# Altium schematic sheet RF.SchDoc(RF)
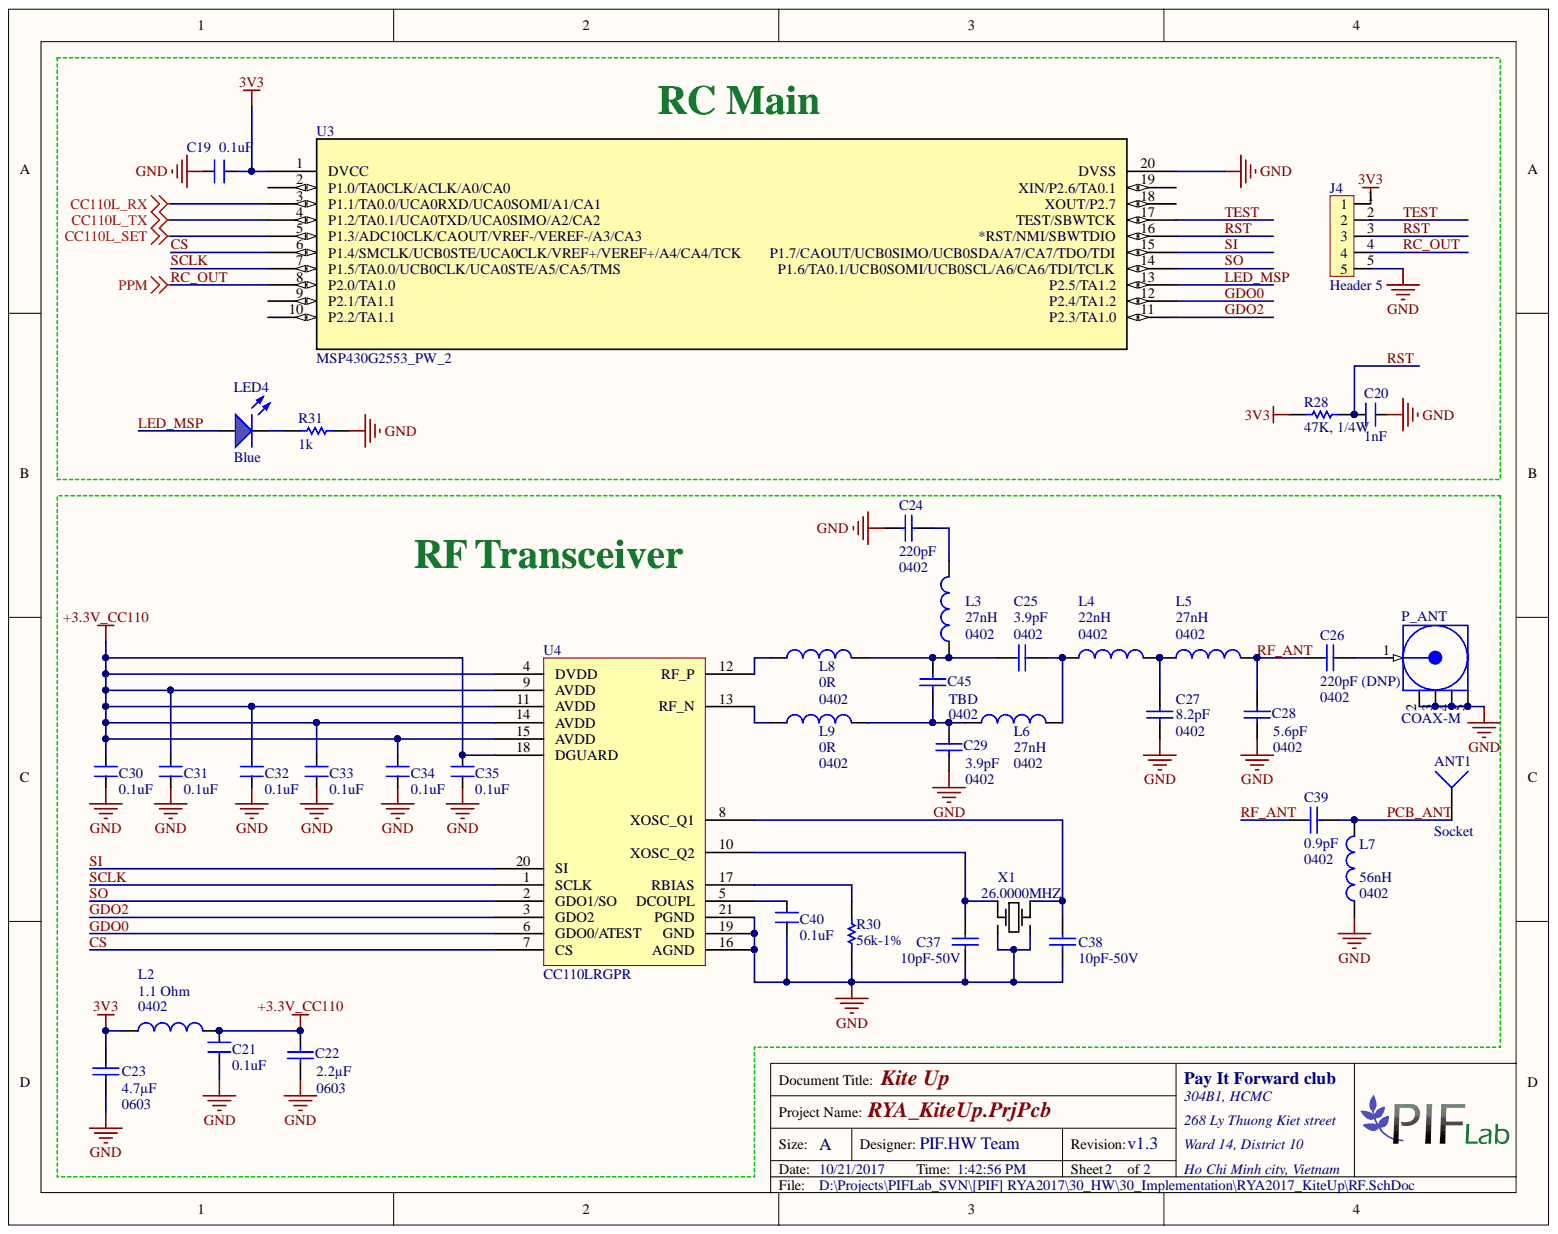
[diagram p0: RF.SchDoc]

## Parts
ANT1  Socket  [433_Antenna]
C19  0.1uF  [CAP 0603(1508)]
C20  1nF  [CAP 0603(1508)]
C21  0.1uF  [CAP 0603(1508)]
C22  Package / Case: 0603  Capacitance: 2.2µF  [CAP 0603(1508)]  (hidden comment: 2.2UF 10V X5R 0603)
C23  Package / Case: 0603  Capacitance: 4.7µF  [CAP 0603(1508)]  (hidden comment: 4.7UF 10V X5R 0603)
C24  Capacitance: 220pF  [C0402_TYPE2]
C25  Package / Case: 0402  Capacitance: 3.9pF  [C0402_TYPE2]
C26  Package / Case: 0402  [C0402_TYPE2]
C27  Package / Case: 0402  Capacitance: 8.2pF  [C0402_TYPE2]
C28  Package / Case: 0402  Capacitance: 5.6pF  [C0402_TYPE2]
C29  Package / Case: 0402  Capacitance: 3.9pF  [C0402_TYPE2]
C30  0.1uF  [CAP 0603(1508)]
C31  0.1uF  [CAP 0603(1508)]
C32  0.1uF  [CAP 0603(1508)]
C33  0.1uF  [CAP 0603(1508)]
C34  0.1uF  [CAP 0603(1508)]
C35  0.1uF  [CAP 0603(1508)]
C37  10pF-50V  [C0402_TYPE2]
C38  10pF-50V  [C0402_TYPE2]
C39  Capacitance: 0.9pF  [C0402_TYPE2]
C40  0.1uF  [CAP 0603(1508)]
C45  Package / Case: 0402  Capacitance: TBD  [C0402_TYPE2]  (no BOM)
J4  Header 5  [Header 5]
L2  Package / Case: 0402  [L0402_TYPE2]
L3  Package / Case: 0402  Inductance: 27nH  [L0402_TYPE2]
L4  Package / Case: 0402  [L0402_TYPE2]
L5  Package / Case: 0402  [L0402_TYPE2]
L6  Package / Case: 0402  Inductance: 27nH  [L0402_TYPE2]
L7  Package / Case: 0402  Inductance: 56nH  [L0402_TYPE2]
L8  Inductance: 0R  [L0402_TYPE2]
L9  Package / Case: 0402  Inductance: 0R  [L0402_TYPE2]
LED4  value Blue  [LED0805BLUE]  (hidden comment: LED_BLUE)
P_ANT  COAX-M  [MMCX2.54-V5]
R28  47K, 1/4W  [RES 0603(1508)]
R30  56k-1%  [R0402_TYPE2]
R31  1k  [RES 0603(1508)]
U3  MSP430G2553_PW_2  [PW20]
U4  CC110LRGPR  [VQFN20]
X1  26.0000MHZ  [Crystal-3.2x2.5]

## Nets
3V3: C19.1 C23.2 J4.1 L2.2 R28.1 U3.1(DVCC)
+3.3V_CC110: C21.2 C22.2 C30.2 C31.2 C32.2 C33.2 C34.2 C35.2 L2.1 U4.4(DVDD) U4.9(AVDD) U4.11(AVDD) U4.14(AVDD) U4.15(AVDD) U4.18(DGUARD)
CC110L_RX: U3.3(P1.1/TA0.0/UCA0RXD/UCA0SOMI/A1/CA1)
CC110L_SET: U3.5(P1.3/ADC10CLK/CAOUT/VREF-/VEREF-/A3/CA3)
CC110L_TX: U3.4(P1.2/TA0.1/UCA0TXD/UCA0SIMO/A2/CA2)
GND: C19.2 C20.2 C21.1 C22.1 C23.1 C24.1 C27.1 C28.1 C29.2 C30.1 C31.1 C32.1 C33.1 C34.1 C35.1 C37.1 C38.1 C40.1 J4.5 L7.2 P_ANT.2 P_ANT.3 P_ANT.4 P_ANT.5 R30.1 R31.1 U3.20(DVSS) U4.16(AGND) U4.19(GND) U4.21(PGND) X1.2 X1.4
CS: U3.6(P1.4/SMCLK/UCB0STE/UCA0CLK/VREF+/VEREF+/A4/CA4/TCK) U4.7(CS)
GDO0: U3.12(P2.4/TA1.2) U4.6(GDO0/ATEST)
GDO2: U3.11(P2.3/TA1.0) U4.3(GDO2)
LED_MSP: LED4.1 U3.13(P2.5/TA1.2)
PCB_ANT: ANT1.1 C39.2 L7.1
RC_OUT: J4.4 U3.8(P2.0/TA1.0)
RF_ANT: C26.1 C28.2 C39.1 L5.1
RST: C20.1 J4.3 R28.2 U3.16(*RST/NMI/SBWTDIO)
SCLK: U3.7(P1.5/TA0.0/UCB0CLK/UCA0STE/A5/CA5/TMS) U4.1(SCLK)
SI: U3.15(P1.7/CAOUT/UCB0SIMO/UCB0SDA/A7/CA7/TDO/TDI) U4.20(SI)
SO: U3.14(P1.6/TA0.1/UCB0SOMI/UCB0SCL/A6/CA6/TDI/TCLK) U4.2(GDO1/SO)
TEST: J4.2 U3.17(TEST/SBWTCK)
NetC24_2: C24.2 L3.1
NetC25_1: C25.1 C45.1 L3.2 L8.1
NetC25_2: C25.2 L4.2 L6.1
NetC26_2: C26.2 P_ANT.1
NetC27_2: C27.2 L4.1 L5.2
NetC29_1: C29.1 C45.2 L6.2 L9.1
NetC37_2: C37.2 U4.10(XOSC_Q2) X1.1
NetC38_2: C38.2 U4.8(XOSC_Q1) X1.3
NetC40_2: C40.2 U4.5(DCOUPL)
NetL8_2: L8.2 U4.12(RF_P)
NetL9_2: L9.2 U4.13(RF_N)
NetLED4_2: LED4.2 R31.2
NetR30_2: R30.2 U4.17(RBIAS)

Not connected: U3 2(P1.0/TA0CLK/ACLK/A0/CA0) 9(P2.1/TA1.1) 10(P2.2/TA1.1) 18(XOUT/P2.7) 19(XIN/P2.6/TA0.1)

## Library data of the parts
C19-C21 C30-C35 C40 = CAP_NONPOL: Ceramic capacitor; Component Kind: Standard; Library Name: libbeagle.SchLib
C22 = 100nF-16V-C0402: CAP CER 2.2UF 10V X5R 0603; Manufacturer Part Number: CC0603KRX5R6BB225; Manufacturer: Yageo; Tolerance: ±10%; Voltage - Rated: 10V; Thickness (Max): 0.035" (0.90mm); Temperature Coefficient: X5R; Supplier Part Number 1: 311-1451-2-ND; Supplier 1: Digi-Key; Stock 1: 360000; Size / Dimension: 0.063" L x 0.031" W (1.60mm x 0.80mm); RoHS: RoHS Compliant; Ratings: -; Pricing 1: 4000=0.01939, 8000=0.01804, 12000=0.01714, 28000=0.01624, 100000=0.01229 (USD); Packaging: Tape & Reel (TR); Operating Temperature: -55°C ~ 85°C; Mounting Type: Surface Mount, MLCC; Library Name: Capacitor_0402.SchLib; Lead Style: -; Lead Spacing: -; Height - Seated (Max): -; Features: -; ComponentLink1URL: http://www.yageo.com/documents/recent/UPY-GPHC_X5R_4V-to-50V-21.pdf; ComponentLink1Description: http://www.yageo.com/documents/recent/UPY-GPHC_X5R_4V-to-50V-21.pdf; Component Kind: Standard; Category: Capacitors; Applications: General Purpose
C23 = 10pF-50V-C0402-5%: CAP CER 4.7UF 10V X5R 0603; Manufacturer Part Number: CC0603KRX5R6BB475; Manufacturer: Yageo; Tolerance: ±10%; Voltage - Rated: 10V; Thickness (Max): 0.035" (0.90mm); Temperature Coefficient: X5R; Supplier Part Number 1: 311-1455-1-ND; Supplier 1: Digi-Key; Stock 1: 521169; Size / Dimension: 0.063" L x 0.031" W (1.60mm x 0.80mm); RoHS: RoHS Compliant; Ratings: -; Pricing 1: 1=0.15, 10=0.1, 25=0.0752, 100=0.0476, 250=0.04008, 500=0.03356, 1000=0.02755 (USD); Packaging: Cut Tape (CT); Operating Temperature: -55°C ~ 85°C; Mounting Type: Surface Mount, MLCC; Library Name: Capacitor_0402.SchLib; Lead Style: -; Lead Spacing: -; Height - Seated (Max): -; Features: -; ComponentLink1URL: http://www.yageo.com/documents/recent/UPY-GPHC_X5R_4V-to-50V-21.pdf; ComponentLink1Description: http://www.yageo.com/documents/recent/UPY-GPHC_X5R_4V-to-50V-21.pdf; Component Kind: Standard; Category: Capacitors; Applications: General Purpose
C24 C39 = 3.3pF-50V-C0402-0.25pF: CAP CER 3.9PF 50V NP0 0402; Manufacturer Part Number: GRM1555C1H221JA01D; Manufacturer: Murata Electronics North America; Tolerance: ±5%; Temperature Coefficient: C0G, NP0; Voltage - Rated: 50V; Thickness (Max): 0.022" (0.55mm); Supplier Part Number 1: 490-1293-2-ND; Supplier 1: Digi-Key; Stock 1: 470000; Size / Dimension: 0.039" L x 0.020" W (1.00mm x 0.50mm); RoHS: RoHS Compliant; Ratings: -; Pricing 1: 10000=0.00336, 20000=0.0032, 30000=0.00304, 50000=0.00272, 100000=0.0022 (USD); Packaging: Tape & Reel (TR); Package / Case: 0402; Operating Temperature: -55°C ~ 125°C; Mounting Type: Surface Mount, MLCC; Library Name: Capacitor_0402.SchLib; Lead Style: -; Lead Spacing: -; Height - Seated (Max): -; Features: -; ComponentLink1URL: http://www.murata.com/~/media/webrenewal/support/library/catalog/products/capacitor/mlcc/c02e.pdf; ComponentLink1Description: http://www.murata.com/~/media/webrenewal/support/library/catalog/products/capacitor/mlcc/c02e.pdf; Component Kind: Standard; Category: Capacitors; Applications: General Purpose
C25 C29 C45 = 3.3pF-50V-C0402-0.25pF: CAP CER 3.9PF 50V NP0 0402; Manufacturer Part Number: GRM1555C1H3R9CZ01D; Manufacturer: Murata Electronics North America; Tolerance: ±0.25pF; Temperature Coefficient: C0G, NP0; Voltage - Rated: 50V; Thickness (Max): 0.022" (0.55mm); Supplier Part Number 1: 490-1272-2-ND; Supplier 1: Digi-Key; Stock 1: 0; Size / Dimension: 0.039" L x 0.020" W (1.00mm x 0.50mm); RoHS: RoHS Compliant; Ratings: -; Pricing 1: 10000=0 (USD); Packaging: Tape & Reel (TR); Operating Temperature: -55°C ~ 125°C; Mounting Type: Surface Mount, MLCC; Library Name: Capacitor_0402.SchLib; Lead Style: -; Lead Spacing: -; Height - Seated (Max): -; Features: -; ComponentLink1URL: http://media.digikey.com/pdf/Data%20Sheets/Murata%20PDFs/Chip%20Monolithic%20Ceramic%20Caps.pdf; ComponentLink1Description: http://media.digikey.com/pdf/Data%20Sheets/Murata%20PDFs/Chip%20Monolithic%20Ceramic%20Caps.pdf; Category: Capacitors; Applications: General Purpose
  C25 C29: Component Kind: Standard
C26 = 3.3pF-50V-C0402-0.25pF: CAP CER 3.9PF 50V NP0 0402; Manufacturer Part Number: GRM1555C1H221JA01D; Manufacturer: Murata Electronics North America; Tolerance: ±5%; Temperature Coefficient: C0G, NP0; Capacitance: 220pF (DNP); Voltage - Rated: 50V; Thickness (Max): 0.022" (0.55mm); Supplier Part Number 1: 490-1293-2-ND; Supplier 1: Digi-Key; Stock 1: 470000; Size / Dimension: 0.039" L x 0.020" W (1.00mm x 0.50mm); RoHS: RoHS Compliant; Ratings: -; Pricing 1: 10000=0.00336, 20000=0.0032, 30000=0.00304, 50000=0.00272, 100000=0.0022 (USD); Packaging: Tape & Reel (TR); Operating Temperature: -55°C ~ 125°C; Mounting Type: Surface Mount, MLCC; Library Name: Capacitor_0402.SchLib; Lead Style: -; Lead Spacing: -; Height - Seated (Max): -; Features: -; ComponentLink1URL: http://www.murata.com/~/media/webrenewal/support/library/catalog/products/capacitor/mlcc/c02e.pdf; ComponentLink1Description: http://www.murata.com/~/media/webrenewal/support/library/catalog/products/capacitor/mlcc/c02e.pdf; Component Kind: Standard; Category: Capacitors; Applications: General Purpose
C27 = 8.2pF-50V-C0402-0.5pF: GRM1555C1H8R2CZ01D; Manufacturer: Murata Electronics North America; Voltage - Rated: 50V; Tolerance: ±0.25pF; Temperature Coefficient: C0G, NP0; Thickness (Max): 0.022" (0.55mm); Supplier Part Number 1: GRM1555C1H8R2CZ01D-ND; Supplier 1: Digi-Key; Stock 1: 0; Size / Dimension: 0.039" L x 0.020" W (1.00mm x 0.50mm); RoHS: RoHS Compliant; Ratings: -; Pricing 1: 60000=0 (USD); Packaging: Tape & Reel (TR); Operating Temperature: -55°C ~ 125°C; Mounting Type: Surface Mount, MLCC; Manufacturer Part Number: GRM1555C1H8R2CZ01D; Library Name: Capacitor_0402.SchLib; Lead Style: -; Lead Spacing: -; Height - Seated (Max): -; Features: -; ComponentLink1URL: http://media.digikey.com/pdf/Data%20Sheets/Murata%20PDFs/Chip%20Monolithic%20Ceramic%20Caps.pdf; ComponentLink1Description: http://media.digikey.com/pdf/Data%20Sheets/Murata%20PDFs/Chip%20Monolithic%20Ceramic%20Caps.pdf; Component Kind: Standard; Category: Capacitors; Applications: General Purpose
C28 = 5.6pF-50V-C0402-0.5pF: CAP CER 5.6PF 50V NP0 0402; Manufacturer Part Number: GRM1555C1H5R6CZ01D; Manufacturer: Murata Electronics North America; Tolerance: ±0.25pF; Temperature Coefficient: C0G, NP0; Voltage - Rated: 50V; Thickness (Max): 0.022" (0.55mm); Supplier Part Number 1: GRM1555C1H5R6CZ01D-ND; Supplier 1: Digi-Key; Stock 1: 0; Size / Dimension: 0.039" L x 0.020" W (1.00mm x 0.50mm); RoHS: RoHS Compliant; Ratings: -; Pricing 1: 60000=0 (USD); Packaging: Tape & Reel (TR); Operating Temperature: -55°C ~ 125°C; Mounting Type: Surface Mount, MLCC; Library Name: Capacitor_0402.SchLib; Lead Style: -; Lead Spacing: -; Height - Seated (Max): -; Features: -; ComponentLink1URL: http://media.digikey.com/pdf/Data%20Sheets/Murata%20PDFs/Chip%20Monolithic%20Ceramic%20Caps.pdf; ComponentLink1Description: http://media.digikey.com/pdf/Data%20Sheets/Murata%20PDFs/Chip%20Monolithic%20Ceramic%20Caps.pdf; Component Kind: Standard; Category: Capacitors; Applications: General Purpose
C37 C38 = 10pF-50V-C0402-5%: CAP CER 10PF 50V NPO 0402; Manufacturer Part Number: CC0402JRNPO9BN100; Manufacturer: Yageo; Unit Price: 0.00312; Library Name: Capacitor_0402.SchLib; Digi-Key Part Number: 311-1014-1-ND; Component Kind: Standard
L2 = 8.2nH-500mA-0402: FERRITE BEAD 1 KOHM 0402 1LN; Manufacturer Part Number: BLM15HG102SN1D; Manufacturer: Murata Electronics North America; Number of Lines: 1; Impedance @ Frequency: 1 kOhm @ 100MHz; Filter Type: Signal Line; DC Resistance (DCR) (Max): 1.1 Ohm; Current Rating (Max): 250mA; Supplier Part Number 1: 490-3999-2-ND; Supplier 1: Digi-Key; Stock 1: 230000; Size / Dimension: 0.039" L x 0.020" W (1.00mm x 0.50mm); RoHS: RoHS Compliant; Ratings: -; Pricing 1: 10000=0.0509, 20000=0.04844, 30000=0.04713 (USD); Packaging: Tape & Reel (TR); Operating Temperature: -55°C ~ 125°C; Mounting Type: Surface Mount; Library Name: Inductor.SchLib; Height (Max): 0.022" (0.55mm); ComponentLink1URL: http://search.murata.co.jp/Ceramy/image/img/PDF/ENG/L0110S0101BLM15H.pdf; ComponentLink1Description: http://search.murata.co.jp/Ceramy/image/img/PDF/ENG/L0110S0101BLM15H.pdf; Component Kind: Standard; Category: Filters
L3 L5-L9 = 8.2nH-500mA-0402: FIXED IND 27NH 300MA 460 MOHM; Manufacturer Part Number: LQG15HS27NJ02D; Manufacturer: Murata Electronics North America; Type: Multilayer; Tolerance: ±5%; Shielding: Unshielded; Q @ Freq: 8 @ 100MHz; Material - Core: Air; Frequency - Test: 100MHz; Frequency - Self Resonant: 1.7GHz; DC Resistance (DCR): 460 mOhm Max; Supplier Part Number 1: 490-2628-2-ND; Supplier 1: Digi-Key; Stock 1: 120000; Size / Dimension: 0.039" L x 0.020" W (1.00mm x 0.50mm); RoHS: RoHS Compliant; Ratings: -; Pricing 1: 10000=0.01872, 30000=0.01814, 50000=0.01755, 100000=0.01697 (USD); Packaging: Tape & Reel (TR); Operating Temperature: -55°C ~ 125°C; Mounting Type: Surface Mount; Library Name: Inductor.SchLib; Height - Seated (Max): 0.022" (0.55mm); Current Rating: 300mA; Current - Saturation: -; ComponentLink1URL: http://search.murata.co.jp/Ceramy/image/img/PDF/ENG/L0075S0083LQG15HS.pdf; ComponentLink1Description: http://search.murata.co.jp/Ceramy/image/img/PDF/ENG/L0075S0083LQG15HS.pdf; Component Kind: Standard; Category: Inductors, Coils, Chokes
  L5: Inductance: 27nH
  L8: Package / Case: 0402
L4 = 8.2nH-500mA-0402: FIXED IND 22NH 300MA 420 MOHM; Manufacturer Part Number: LQG15HS22NJ02D; Manufacturer: Murata Electronics North America; Type: Multilayer; Tolerance: ±5%; Shielding: Unshielded; Q @ Freq: 8 @ 100MHz; Material - Core: Air; Frequency - Test: 100MHz; Frequency - Self Resonant: 1.9GHz; DC Resistance (DCR): 420 mOhm Max; Supplier Part Number 1: 490-2627-1-ND; Supplier 1: Digi-Key; Stock 1: 250711; Size / Dimension: 0.039" L x 0.020" W (1.00mm x 0.50mm); RoHS: RoHS Compliant; Ratings: -; Pricing 1: 1=0.1, 10=0.059, 25=0.0528, 50=0.0456, 100=0.041, 250=0.03804, 500=0.0351, 750=0.03335, 1000=0.03042 (USD); Packaging: Cut Tape (CT); Operating Temperature: -55°C ~ 125°C; Mounting Type: Surface Mount; Library Name: Inductor.SchLib; Inductance: 22nH; Height - Seated (Max): 0.022" (0.55mm); Current Rating: 300mA; Current - Saturation: -; ComponentLink1URL: http://search.murata.co.jp/Ceramy/image/img/PDF/ENG/L0075S0083LQG15HS.pdf; ComponentLink1Description: http://search.murata.co.jp/Ceramy/image/img/PDF/ENG/L0075S0083LQG15HS.pdf; Component Kind: Standard; Category: Inductors, Coils, Chokes
LED4 = LED_BLUE: LED Blue; Unit Price: 0.0476; ComponentLink1Description: Datasheet; Component Kind: Standard; Library Name: libbeagle.SchLib; ComponentLink1URL: D:\Dropbox\Datasheets\Opto and Illumination\LEDs\SMD LED.pdf
P_ANT = COAX-M: RF Coaxial PCB Connector, MMCX; Thru-Hole, Vertical Mount Plug,  50 Ohm Impedance; PCB_Layout: Complies with manufacturer's recommendation.; PackageDocument: Rev. A, 16-Jun-1997; DrillSize: Corresponds to nominal value.; Published: 15-Jul-2004; PackageReference: 908-21103; Library Name: Miscellaneous Connectors.IntLib; LatestRevisionNote: Component Replaced.; LatestRevisionDate: 15-Jul-2004; DatasheetDocument: 30-May-2003; ComponentLink1URL: http://www.amphenolrf.com/; ComponentLink1Description: Manufacturer Link; Component Kind: Standard; Publisher: Altium Limited
R28 R31 = Res 1/4W: Resistor through hole 1/4W; Component Kind: Standard; Library Name: libbeagle.SchLib
R30 = 56k-1%-R0402: RES 56.0K OHM 1/16W 1% 0402 SMD; Manufacturer Part Number: RC0402FR-0756KL; Manufacturer: Yageo; Unit Price: 0.00149; Library Name: Resistor_0402.SchLib; Digi-Key Part Number: 311-56.0KLRTR-ND; Component Kind: Standard
U3 = MSP430G2553_PW_2: Imported; Manufacturer_Part_Number: msp430g2553_pw_20; Vendor: Texas Instruments; PIN_COUNT: 20; PACKAGE_DESIGNATOR: PW; INDUSTRY_STD_PKG_TYPE: TSSOP; FAMILY_NAME: Value Line; Value: Value; Type: Type; RefDes: RefDes; Manufacturer: Texas Instruments; Library Name: msp430g2553.SchLib; GENERIC_PART_NUMBER: MSP430G2553; Datasheet_URL: http://www.ti.com/lit/gpn/MSP430G2553; Component Kind: Standard
U4 = CC110LRGPR: IC RF TXRX ISM<1GHZ 20-VFQFN; Manufacturer: Texas Instruments; Voltage - Supply: 1.8 V ~ 3.6 V; Serial Interfaces: SPI; Sensitivity: -116dBm; RF Family/Standard: General ISM < 1GHz; Power - Output: 12dBm; Modulation: 2FSK, 4FSK, GFSK, MSK, OOK; Frequency: 300MHz ~ 348MHz, 387MHz ~ 464MHz, 779MHz ~ 928MHz; Data Rate (Max): 600kbps; Current - Transmitting: 12.3mA ~ 34.2mA; Current - Receiving: 14.3mA ~ 17.1mA; Type: TxRx Only; Supplier Part Number 1: 296-35719-2-ND; Supplier 1: Digi-Key; Stock 1: 0; RoHS: RoHS Compliant; Protocol: -; Pricing 1: 3000=1.9285, 6000=1.84875, 15000=1.8125 (USD); Packaging: Tape & Reel (TR); Package / Case: 20-VFQFN Exposed Pad; Operating Temperature: -40°C ~ 85°C; Memory Size: -; Manufacturer Part Number: CC110LRGPR; Library Name: Schlib1.SchLib; GPIO: -; ComponentLink1URL: http://www.ti.com/lit/ds/symlink/cc110l.pdf; ComponentLink1Description: http://www.ti.com/lit/ds/symlink/cc110l.pdf; Component Kind: Standard; Category: RF/IF and RFID
X1 = CX3225SB26000D0FFFCC: CRYSTAL 26.0000MHZ 8PF SMD; Manufacturer: AVX Corp/Kyocera Corp; Operating Mode: Fundamental; Frequency Tolerance: ±10ppm; Frequency Stability: ±15ppm; Frequency: 26MHz; ESR (Equivalent Series Resistance): 50 Ohm; Type: MHz Crystal; Supplier Part Number 1: 1253-1354-2-ND; Supplier 1: Digi-Key; Stock 1: 3000; Size / Dimension: 0.126" L x 0.098" W (3.20mm x 2.50mm); RoHS: RoHS Compliant; Ratings: -; Pricing 1: 3000=0.406, 6000=0.392, 15000=0.378 (USD); Packaging: Tape & Reel (TR); Package / Case: 4-SMD, No Lead (DFN, LCC); Operating Temperature: -20°C ~ 70°C; Mounting Type: Surface Mount; Manufacturer Part Number: CX3225SB26000D0FFFCC; Load Capacitance: 8pF; Library Name: Schlib1.SchLib; Height: 0.024" (0.60mm); ComponentLink1URL: http://media.digikey.com/pdf/Data%20Sheets/Optrex%20PDFs/CX3225SByyy00D0FFFCC_Spec.pdf; ComponentLink1Description: http://media.digikey.com/pdf/Data%20Sheets/Optrex%20PDFs/CX3225SByyy00D0FFFCC_Spec.pdf; Component Kind: Standard; Category: Crystals and Oscillators
ANT1 = Socket: Signal Integrity: Connector; Library Name: Miscellaneous Connectors.IntLib; LatestRevisionNote: Re-released for DXP Platform.; LatestRevisionDate: 17-Jul-2002; Description: Socket; Component Kind: Standard; Publisher: Altium Limited
J4 = Header 5: Component Kind: Standard; Library Name: libbeagle.SchLib
